# Altium schematic sheet BLM_2017.SchDoc
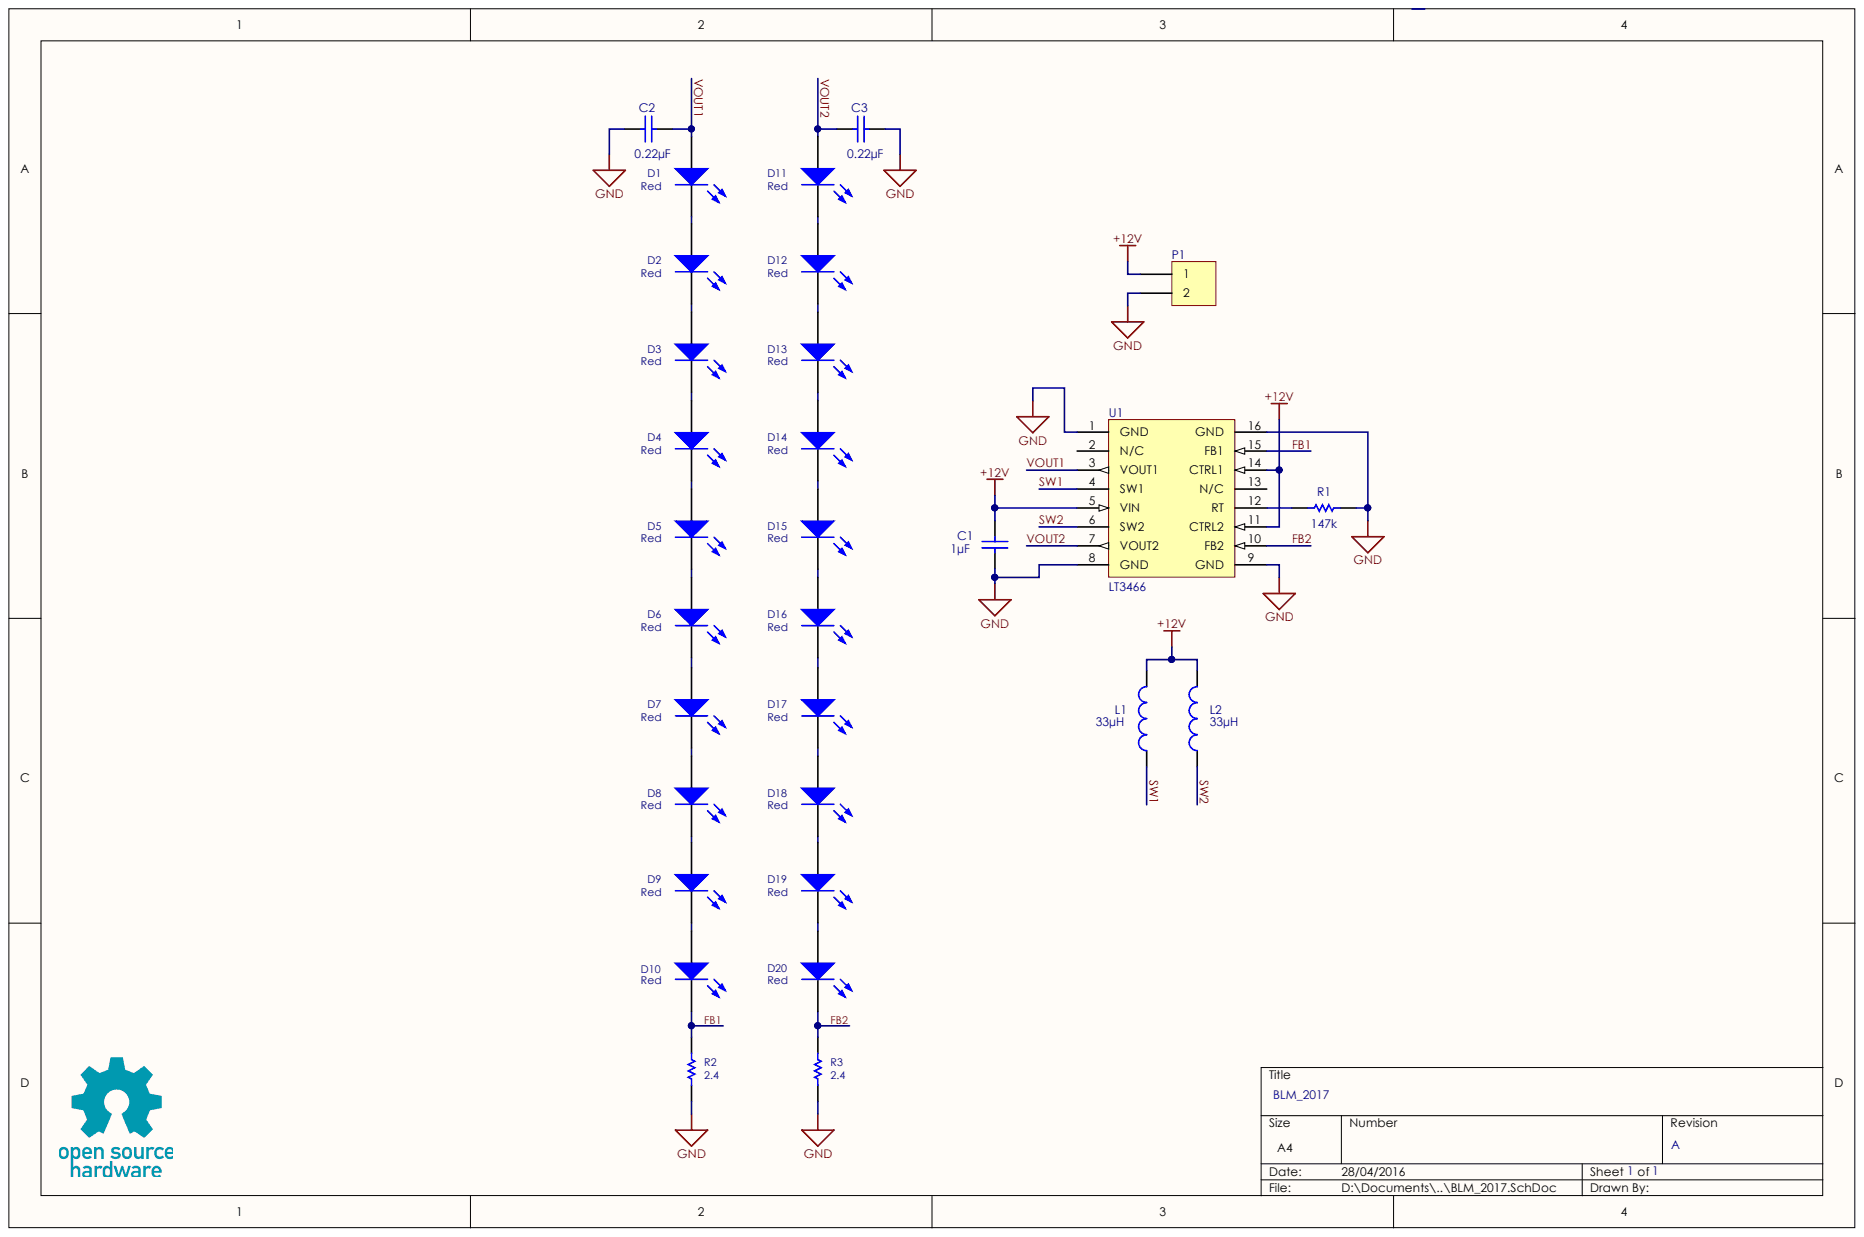
[diagram p0: BLM_2017.SchDoc]

## Parts
C1  value 1µF  [TDK C-Series (2012)]  (hidden comment: Cap Semi)
C2  value 0.22µF  [TDK C-Series (1608)]  (hidden comment: Cap Semi)
C3  value 0.22µF  [TDK C-Series (1608)]  (hidden comment: Cap Semi)
D1  Red  [OPTEK OVS5MxBCR4-Series (2-SMD)]
D2  Red  [OPTEK OVS5MxBCR4-Series (2-SMD)]
D3  Red  [OPTEK OVS5MxBCR4-Series (2-SMD)]
D4  Red  [OPTEK OVS5MxBCR4-Series (2-SMD)]
D5  Red  [OPTEK OVS5MxBCR4-Series (2-SMD)]
D6  Red  [OPTEK OVS5MxBCR4-Series (2-SMD)]
D7  Red  [OPTEK OVS5MxBCR4-Series (2-SMD)]
D8  Red  [OPTEK OVS5MxBCR4-Series (2-SMD)]
D9  Red  [OPTEK OVS5MxBCR4-Series (2-SMD)]
D10  Red  [OPTEK OVS5MxBCR4-Series (2-SMD)]
D11  Red  [OPTEK OVS5MxBCR4-Series (2-SMD)]
D12  Red  [OPTEK OVS5MxBCR4-Series (2-SMD)]
D13  Red  [OPTEK OVS5MxBCR4-Series (2-SMD)]
D14  Red  [OPTEK OVS5MxBCR4-Series (2-SMD)]
D15  Red  [OPTEK OVS5MxBCR4-Series (2-SMD)]
D16  Red  [OPTEK OVS5MxBCR4-Series (2-SMD)]
D17  Red  [OPTEK OVS5MxBCR4-Series (2-SMD)]
D18  Red  [OPTEK OVS5MxBCR4-Series (2-SMD)]
D19  Red  [OPTEK OVS5MxBCR4-Series (2-SMD)]
D20  Red  [OPTEK OVS5MxBCR4-Series (2-SMD)]
L1  value 33µH  [Bourns SRN4018-Series]  (hidden comment: Inductor)
L2  value 33µH  [Bourns SRN4018-Series]  (hidden comment: Inductor)
P1  2-Pin Bare Wire Pads  [2-Pin Bare Wire Pads]  (value not drawn)
R1  value 147k  [Panasonic ERJ-Series (1608)]  (hidden comment: Res1)
R2  value 2.4  [Panasonic ERJ-Series (1608)]  (hidden comment: Res1)
R3  value 2.4  [Panasonic ERJ-Series (1608)]  (hidden comment: Res1)
U1  LT3466  [Linear Technology LT3466 (16-TSSOP)]

## Nets
+12V: C1.2 L1.2 L2.2 P1.1 U1.5(VIN) U1.11(CTRL2) U1.14(CTRL1)
GND: C1.1 C2.2 C3.1 P1.2 R1.1 R2.1 R3.1 U1.1(GND) U1.8(GND) U1.9(GND) U1.16(GND)
FB1: D10.2 R2.2 U1.15(FB1)
FB2: D20.2 R3.2 U1.10(FB2)
SW1: L1.1 U1.4(SW1)
SW2: L2.1 U1.6(SW2)
VOUT1: C2.1 D1.1 U1.3(VOUT1)
VOUT2: C3.2 D11.1 U1.7(VOUT2)
NetD1_2: D1.2 D2.1
NetD2_2: D2.2 D3.1
NetD3_2: D3.2 D4.1
NetD4_2: D4.2 D5.1
NetD5_2: D5.2 D6.1
NetD6_2: D6.2 D7.1
NetD7_2: D7.2 D8.1
NetD8_2: D8.2 D9.1
NetD9_2: D9.2 D10.1
NetD11_2: D11.2 D12.1
NetD12_2: D12.2 D13.1
NetD13_2: D13.2 D14.1
NetD14_2: D14.2 D15.1
NetD15_2: D15.2 D16.1
NetD16_2: D16.2 D17.1
NetD17_2: D17.2 D18.1
NetD18_2: D18.2 D19.1
NetD19_2: D19.2 D20.1
NetR1_2: R1.2 U1.12(RT)
N00005:

Not connected: U1 2(N/C) 13(N/C)

## Library data of the parts
C1 C2 = Cap Semi: Capacitor (Semiconductor SIM Model); Component Kind: Standard; LatestRevisionDate: 29-May-2009; LatestRevisionNote: IPC-7351 Footprint Added.; Library Name: Miscellaneous Devices.IntLib; PackageDescription: Chip Capacitor; PackageReference: C1206; Published: 8-Jun-2000; Signal Integrity: Cap Semi; Simulation: CAP; Supplier 1: Digi-Key; Publisher: Altium Limited
  C1: Supplier Part Number 1: 445-14539-1-ND
  C2: Supplier Part Number 1: 445-7408-1-ND
C3 = Cap Semi: Capacitor (Semiconductor SIM Model); Value: 0.22µF; Component Kind: Standard; LatestRevisionDate: 29-May-2009; LatestRevisionNote: IPC-7351 Footprint Added.; Library Name: Miscellaneous Devices.IntLib; PackageDescription: Chip Capacitor; PackageReference: C1206; Published: 8-Jun-2000; Signal Integrity: Cap Semi; Simulation: CAP; Supplier 1: Digi-Key; Supplier Part Number 1: 445-7408-1-ND; Publisher: Altium Limited
D1-D20 = LED0: Typical INFRARED GaAs LED; Component Kind: Standard; LatestRevisionDate: 08-Jul-2005; LatestRevisionNote: Mech Layer 1 removed.; Library Name: Miscellaneous Devices.IntLib; PackageDescription: LED; 2 Leads; PackageReference: LED-0; Published: 8-Jun-2000; Simulation: LED0; Supplier 1: Digi-Key; Supplier Part Number 1: 365-1546-1-ND; Publisher: Altium Limited
L1 L2 = Inductor: Code_IEC: 1005; Code_JEITA: 0402; Component Kind: Standard; Description: Inductor; LatestRevisionDate: 29-May-2009; LatestRevisionNote: IPC-7351 Footprint Added.; Library Name: Miscellaneous Devices.IntLib; PackageDescription: Chip Inductor; PackageReference: 0402-A; Published: 8-Jun-2000; Signal Integrity: Inductor; Simulation: INDUCTOR; Supplier 1: Digi-Key; Supplier Part Number 1: SRN4018-330MCT-ND; Publisher: Altium Limited
R1-R3 = Res1: Resistor; Component Kind: Standard; LatestRevisionDate: 17-Jul-2002; LatestRevisionNote: Re-released for DXP Platform.; Library Name: Miscellaneous Devices.IntLib; PackageDescription: Axial Device, Thru-Hole; 2 Leads; 0.3 in Pin Spacing; PackageReference: AXIAL-0.3; Published: 8-Jun-2000; Signal Integrity: Res; Simulation: RESISTOR; Supplier 1: Digi-Key; Publisher: Altium Limited
  R1: Supplier Part Number 1: P147KHCT-ND
  R2 R3: Supplier Part Number 1: P10.0HCT-ND
U1 = Linear Technology LT3466: 2-Channel Step-Up LED Driver; Component Kind: Standard; Supplier 1: Digi-Key; Supplier Part Number 1: LT3466EFE#PBF-ND; Library Name: TSAL_2017.SchLib
P1 = 2-Pin Bare Wire Pads: Component Kind: Standard; Description: 2-Pin Bare Wire Pads; Library Name: BLM_2017.SchLib
